# Altium schematic sheet [7]measurement.SchDoc
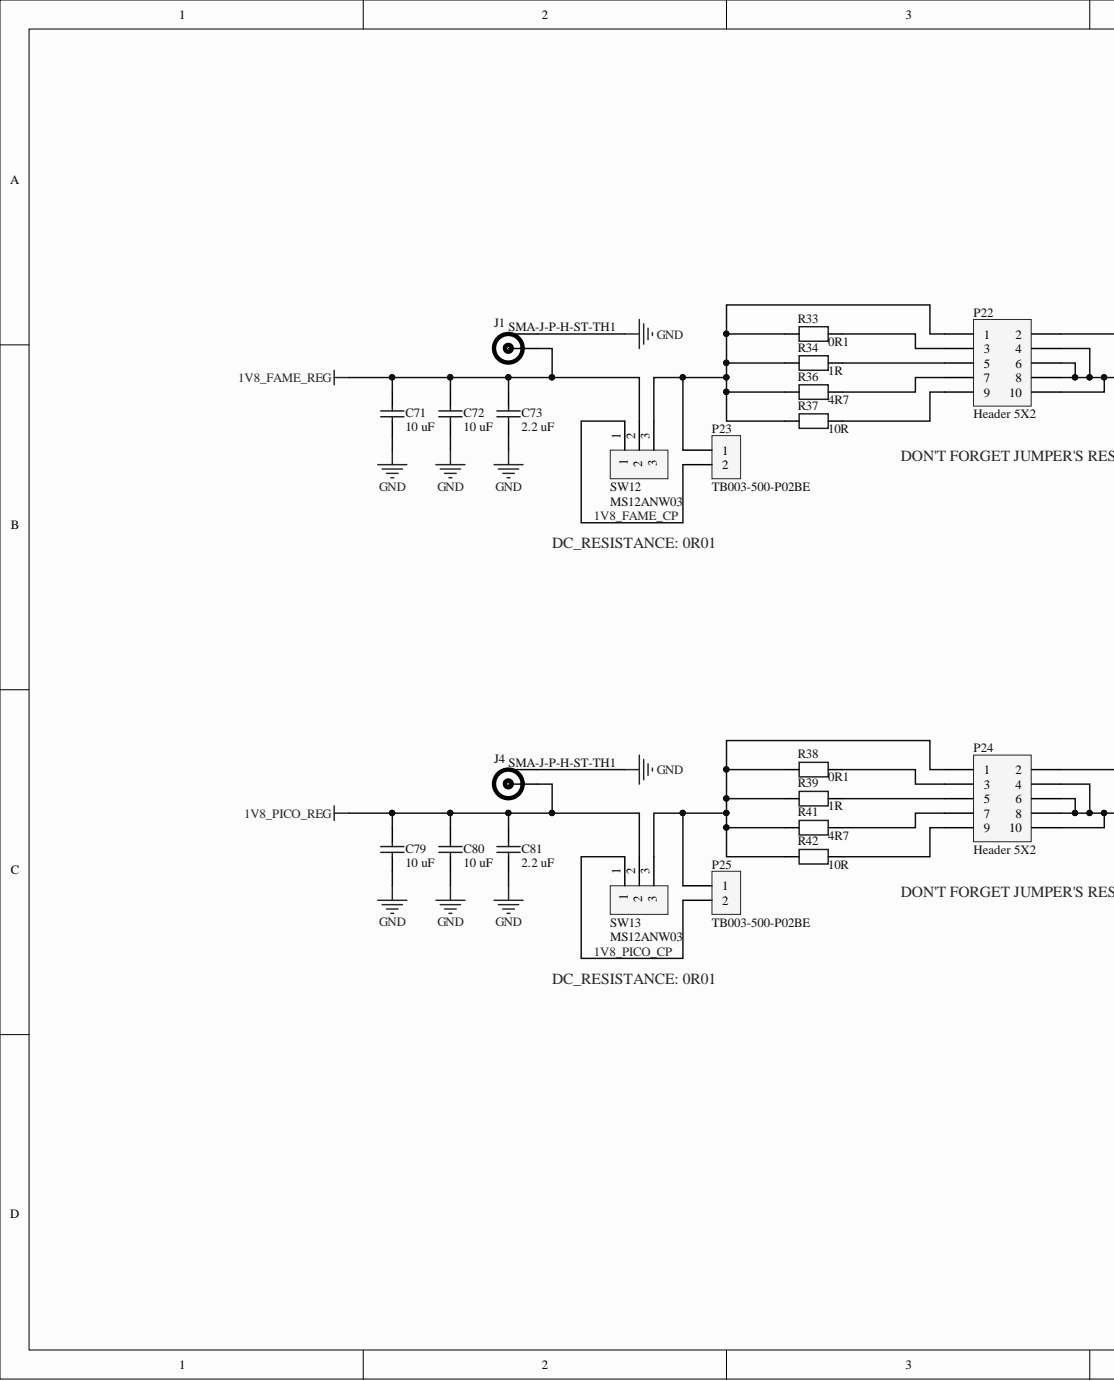
[diagram p0: [7]measurement.SchDoc, left]
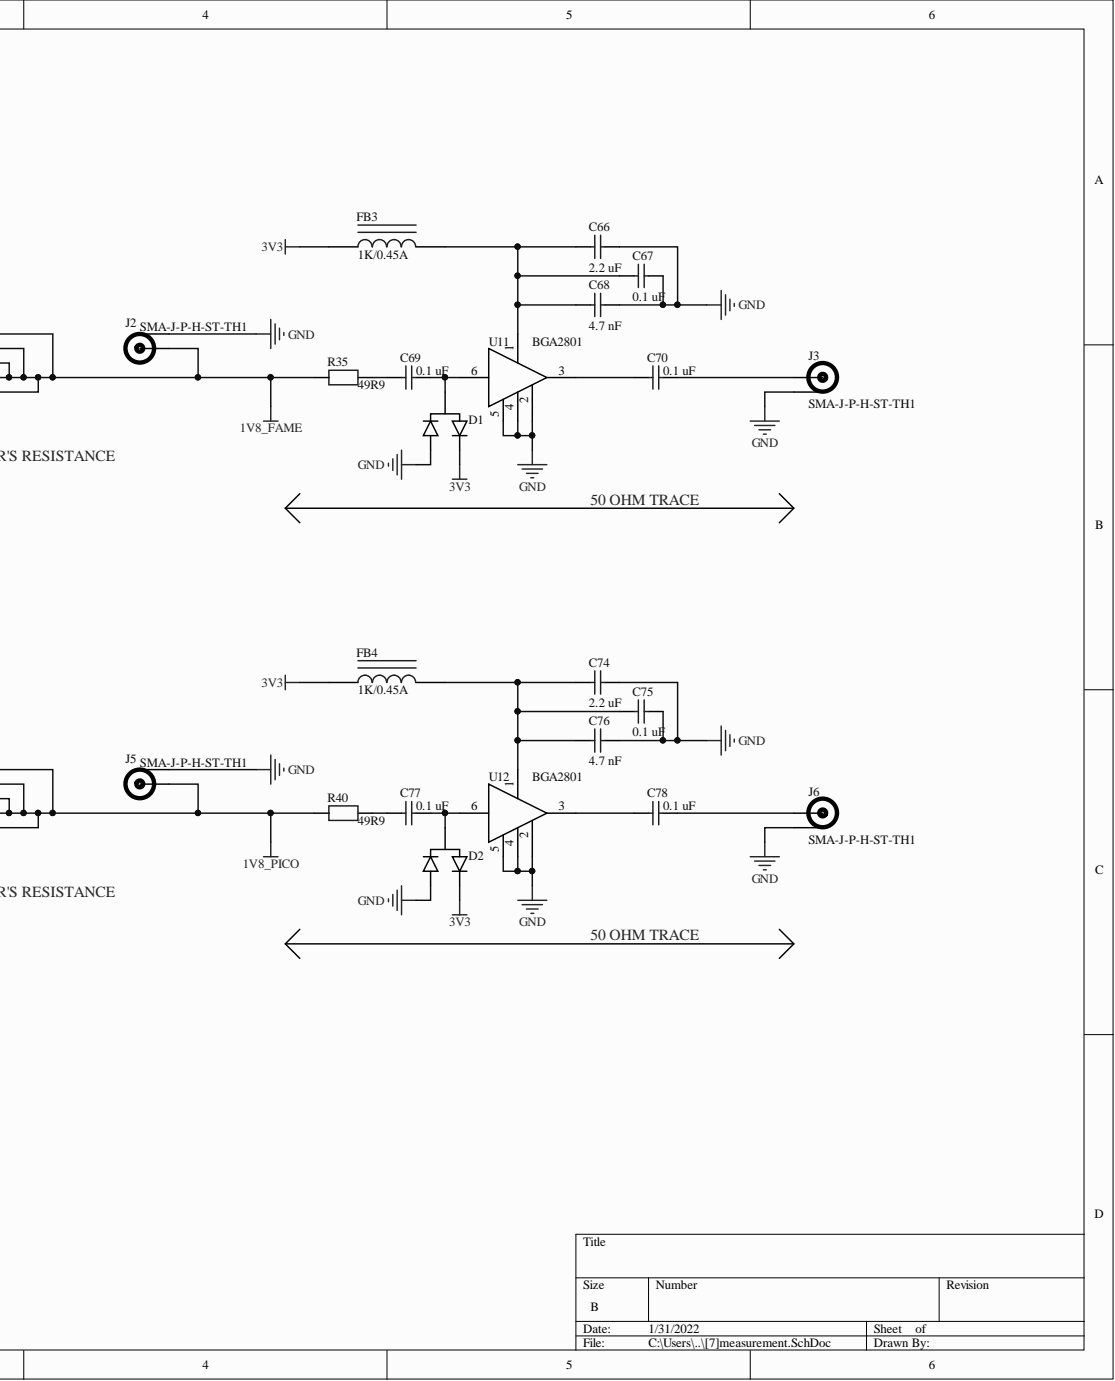
[diagram p1: [7]measurement.SchDoc, right]

## Parts
C66  value 2.2 uF  [0402-CAP-ASM]  (hidden comment: Cap)
C67  value 0.1 uF  [0402-CAP-ASM]  (hidden comment: Cap)
C68  value 4.7 nF  [0402-CAP-ASM]  (hidden comment: Cap)
C69  value 0.1 uF  [0402-CAP-ASM]  (hidden comment: Cap)
C70  value 0.1 uF  [0402-CAP-ASM]  (hidden comment: Cap)
C71  value 10 uF  [0603-CAP-ASM]  (hidden comment: Cap)
C72  value 10 uF  [0603-CAP-ASM]  (hidden comment: Cap)
C73  value 2.2 uF  [0402-CAP-ASM]  (hidden comment: Cap)
C74  value 2.2 uF  [0402-CAP-ASM]  (hidden comment: Cap)
C75  value 0.1 uF  [0402-CAP-ASM]  (hidden comment: Cap)
C76  value 4.7 nF  [0402-CAP-ASM]  (hidden comment: Cap)
C77  value 0.1 uF  [0402-CAP-ASM]  (hidden comment: Cap)
C78  value 0.1 uF  [0402-CAP-ASM]  (hidden comment: Cap)
C79  value 10 uF  [0603-CAP-ASM]  (hidden comment: Cap)
C80  value 10 uF  [0603-CAP-ASM]  (hidden comment: Cap)
C81  value 2.2 uF  [0402-CAP-ASM]  (hidden comment: Cap)
D1  Barrier Diode  [Sot23-3]  (value not drawn)
D2  Barrier Diode  [Sot23-3]  (value not drawn)
FB3  value 1K/0.45A  [0603-CAP-ASM]  (hidden comment: 1K/0A45)
FB4  value 1K/0.45A  [0603-CAP-ASM]  (hidden comment: 1K/0A45)
J1  SMA-J-P-H-ST-TH1  [SMA-J-P-H-ST-TH1]
J2  SMA-J-P-H-ST-TH1  [SMA-J-P-H-ST-TH1]
J3  SMA-J-P-H-ST-TH1  [SMA-J-P-H-ST-TH1]
J4  SMA-J-P-H-ST-TH1  [SMA-J-P-H-ST-TH1]
J5  SMA-J-P-H-ST-TH1  [SMA-J-P-H-ST-TH1]
J6  SMA-J-P-H-ST-TH1  [SMA-J-P-H-ST-TH1]
P22  Header 5X2  [HDR_2x5_2R54_V_M]
P23  TB003-500-P02BE  [TB003-500-P02BE]
P24  Header 5X2  [HDR_2x5_2R54_V_M]
P25  TB003-500-P02BE  [TB003-500-P02BE]
R33  value 0R1  [0805-RES-ASM]  (hidden comment: res)
R34  value 1R  [0805-RES-ASM]  (hidden comment: res)
R35  value 49R9  [0402-RES-ASM]  (hidden comment: res)
R36  value 4R7  [0805-RES-ASM]  (hidden comment: res)
R37  value 10R  [0805-RES-ASM]  (hidden comment: res)
R38  value 0R1  [0805-RES-ASM]  (hidden comment: res)
R39  value 1R  [0805-RES-ASM]  (hidden comment: res)
R40  value 49R9  [0402-RES-ASM]  (hidden comment: res)
R41  value 4R7  [0805-RES-ASM]  (hidden comment: res)
R42  value 10R  [0805-RES-ASM]  (hidden comment: res)
SW12  MS12ANW03  [MS12ANW03]
SW13  MS12ANW03  [MS12ANW03]
U11  BGA2801  [SOT363]
U12  BGA2801  [SOT363]

## Nets
1V8_FAME: J2.1 P22.2 P22.4 P22.6 P22.8 P22.10 R35.1
1V8_FAME_REG: C71.2 C72.2 C73.2 J1.1 SW12.2
1V8_PICO: J5.1 P24.2 P24.4 P24.6 P24.8 P24.10 R40.1
1V8_PICO_REG: C79.2 C80.2 C81.2 J4.1 SW13.2
3V3: D1.2 D2.2 FB3.1 FB4.1
GND: C66.1 C67.1 C68.1 C71.1 C72.1 C73.1 C74.1 C75.1 C76.1 C79.1 C80.1 C81.1 D1.1 D2.1 J1.2 J2.2 J3.2 J4.2 J5.2 J6.2 U11.2 U11.4 U11.5 U12.2 U12.4 U12.5
1V8_FAME_CP: P23.2 SW12.1
1V8_PICO_CP: P25.2 SW13.1
NetC66_2: C66.2 C67.2 C68.2 FB3.2 U11.1
NetC69_1: C69.1 R35.2
NetC69_2: C69.2 D1.3 U11.6
NetC70_1: C70.1 U11.3
NetC70_2: C70.2 J3.1
NetC74_2: C74.2 C75.2 C76.2 FB4.2 U12.1
NetC77_1: C77.1 R40.2
NetC77_2: C77.2 D2.3 U12.6
NetC78_1: C78.1 U12.3
NetC78_2: C78.2 J6.1
NetP22_1: P22.1 P23.1 R33.1 R34.1 R36.1 R37.1 SW12.3
NetP22_3: P22.3 R33.2
NetP22_5: P22.5 R34.2
NetP22_7: P22.7 R36.2
NetP22_9: P22.9 R37.2
NetP24_1: P24.1 P25.1 R38.1 R39.1 R41.1 R42.1 SW13.3
NetP24_3: P24.3 R38.2
NetP24_5: P24.5 R39.2
NetP24_7: P24.7 R41.2
NetP24_9: P24.9 R42.2

## Library data of the parts
C66 C73 C74 C81 = Cap: CAP CER 2.2UF 16V X5R 0402; Component Kind: Standard; Library Name: Miscellaneous Devices.IntLib; Supplier Part Number: 490-10456-1-ND
C67-C70 C75-C78 = Cap: CAP CER 0.1UF 10V X5R 0402; Component Kind: Standard; Library Name: Miscellaneous Devices.IntLib; Supplier Part Number: 587-1227-1-ND
C71 C72 C79 C80 = Cap: CAP CER 10UF 10V X5R 0603; Component Kind: Standard; Library Name: Miscellaneous Devices.IntLib; Supplier Part Number: 1276-1192-1-ND
D1 D2 = Barrier Diode: DIODE SCHOTTKY 30V 150MA SOT23; Component Kind: Standard; Library Name: Mylib..SchLib; Supplier Part Number: 1655-2283-1-ND
FB3 FB4 = Inductor Iron: FERRITE BEAD 1 KOHM 0603 1LN; Component Kind: Standard; Library Name: Miscellaneous Devices.IntLib; Supplier Part Number: 490-1015-1-ND
J1-J6 = SMA-J-P-H-ST-TH1: CONN SMA JACK STR 50 OHM PCB; Component Kind: Standard; Library Name: Mylib..SchLib; Supplier Part Number: SAM8971-ND
P22 P24 = Header 5X2: CONN HEADER VERT 10POS 2.54MM; Component Kind: Standard; Library Name: Miscellaneous Connectors.IntLib; Supplier Part Number: SAM1028-05-ND
P23 P25 = TB003-500-P02BE: TERMINAL BLOCK, SCREW TYPE, 5.00; Component Kind: Standard; Library Name: Mylib..SchLib; Supplier Part Number: 102-6161-ND
R33 R38 = RESISTOR: RES 0.1 OHM 0.5% 1/4W 0805; Component Kind: Standard; Library Name: Mylib..SchLib; Supplier Part Number: 541-WSL0805R1000DEA18CT-ND
R34 R39 = RESISTOR: RES SMD 1 OHM 1% 1/2W 0805; Component Kind: Standard; Library Name: Mylib..SchLib; Supplier Part Number: P19268CT-ND
R35 R40 = RESISTOR: RES SMD 49.9 OHM 0.1% 1/16W 0402; Component Kind: Standard; Library Name: Mylib..SchLib; Supplier Part Number: A124586CT-ND
R36 R41 = RESISTOR: RES SMD 4.7 OHM 1% 1/3W 0805; Component Kind: Standard; Library Name: Mylib..SchLib; Supplier Part Number: P15995CT-ND
R37 R42 = RESISTOR: RES 10 OHM 1% 1/5W 0805; Component Kind: Standard; Library Name: Mylib..SchLib; Supplier Part Number: 541-3916-1-ND
SW12 SW13 = MS12ANW03: SWITCH SLIDE SPDT 6A 125V; Component Kind: Standard; Library Name: Mylib..SchLib; Supplier Part Number: 360-2385-ND
U11 U12 = BGA2801: IC RF AMP GP 0HZ-2.2GHZ 6TSSOP; Component Kind: Standard; Library Name: Mylib..SchLib; Supplier Part Number: 568-6208-1-ND
